# Altium schematic sheet TRINKIEROCKS P3.SchDoc
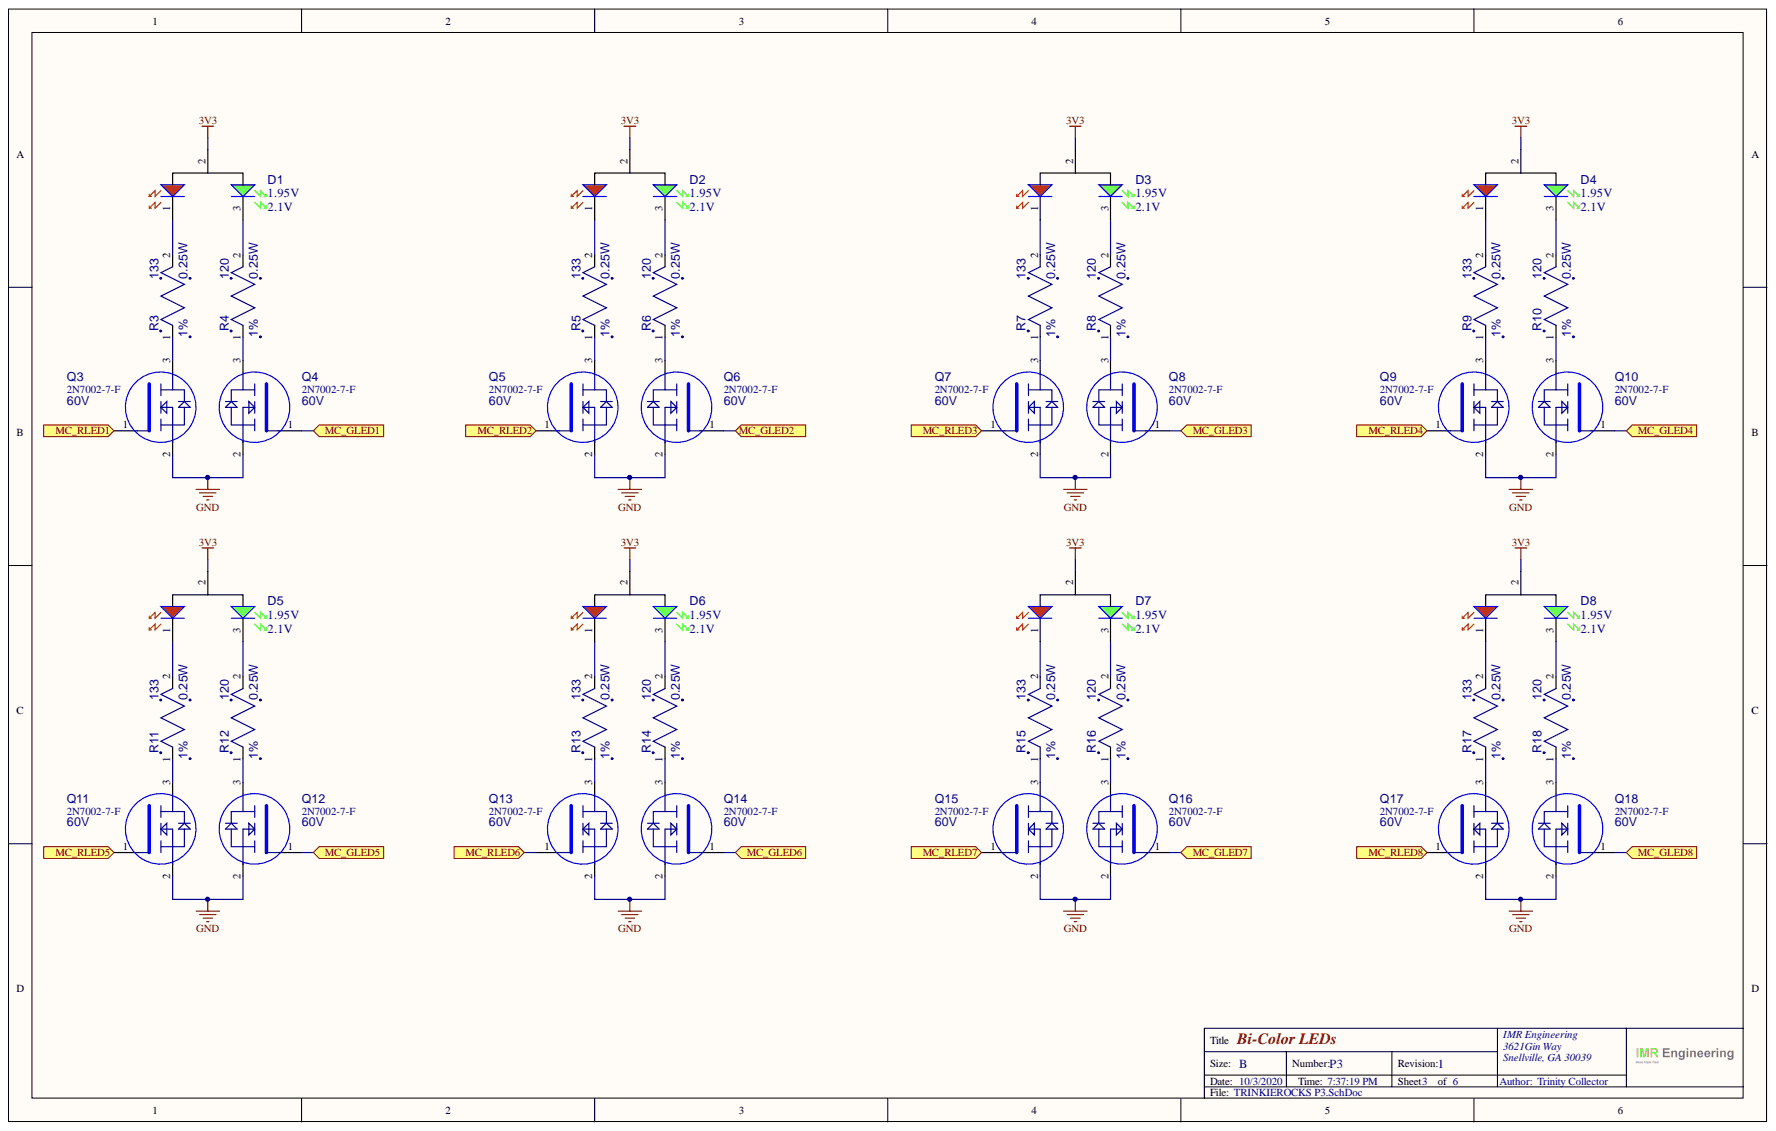
[diagram p0: TRINKIEROCKS P3.SchDoc]

## Parts
D1  Value 1: 1.95V  Value 2: 2.1V  [BICOLOR]  (hidden comment: DIODE_LED_BICOLOR_T1)
D2  Value 1: 1.95V  Value 2: 2.1V  [BICOLOR]  (hidden comment: DIODE_LED_BICOLOR_T1)
D3  Value 1: 1.95V  Value 2: 2.1V  [BICOLOR]  (hidden comment: DIODE_LED_BICOLOR_T1)
D4  Value 1: 1.95V  Value 2: 2.1V  [BICOLOR]  (hidden comment: DIODE_LED_BICOLOR_T1)
D5  Value 1: 1.95V  Value 2: 2.1V  [BICOLOR]  (hidden comment: DIODE_LED_BICOLOR_T1)
D6  Value 1: 1.95V  Value 2: 2.1V  [BICOLOR]  (hidden comment: DIODE_LED_BICOLOR_T1)
D7  Value 1: 1.95V  Value 2: 2.1V  [BICOLOR]  (hidden comment: DIODE_LED_BICOLOR_T1)
D8  Value 1: 1.95V  Value 2: 2.1V  [BICOLOR]  (hidden comment: DIODE_LED_BICOLOR_T1)
Q3  2N7002-7-F  value 60V  [SOT23 - TRANSISTOR]
Q4  2N7002-7-F  value 60V  [SOT23 - TRANSISTOR]
Q5  2N7002-7-F  value 60V  [SOT23 - TRANSISTOR]
Q6  2N7002-7-F  value 60V  [SOT23 - TRANSISTOR]
Q7  2N7002-7-F  value 60V  [SOT23 - TRANSISTOR]
Q8  2N7002-7-F  value 60V  [SOT23 - TRANSISTOR]
Q9  2N7002-7-F  value 60V  [SOT23 - TRANSISTOR]
Q10  2N7002-7-F  value 60V  [SOT23 - TRANSISTOR]
Q11  2N7002-7-F  value 60V  [SOT23 - TRANSISTOR]
Q12  2N7002-7-F  value 60V  [SOT23 - TRANSISTOR]
Q13  2N7002-7-F  value 60V  [SOT23 - TRANSISTOR]
Q14  2N7002-7-F  value 60V  [SOT23 - TRANSISTOR]
Q15  2N7002-7-F  value 60V  [SOT23 - TRANSISTOR]
Q16  2N7002-7-F  value 60V  [SOT23 - TRANSISTOR]
Q17  2N7002-7-F  value 60V  [SOT23 - TRANSISTOR]
Q18  2N7002-7-F  value 60V  [SOT23 - TRANSISTOR]
R3  value 133  POWER: 0.25W  TOLERANCE: 1%  [RES_0805]  (hidden comment: RK73H2ATTD1330F)
R4  value 120  POWER: 0.25W  TOLERANCE: 1%  [RES_0805]  (hidden comment: RK73H2ATTD1200F)
R5  value 133  POWER: 0.25W  TOLERANCE: 1%  [RES_0805]  (hidden comment: RK73H2ATTD1330F)
R6  value 120  POWER: 0.25W  TOLERANCE: 1%  [RES_0805]  (hidden comment: RK73H2ATTD1200F)
R7  value 133  POWER: 0.25W  TOLERANCE: 1%  [RES_0805]  (hidden comment: RK73H2ATTD1330F)
R8  value 120  POWER: 0.25W  TOLERANCE: 1%  [RES_0805]  (hidden comment: RK73H2ATTD1200F)
R9  value 133  POWER: 0.25W  TOLERANCE: 1%  [RES_0805]  (hidden comment: RK73H2ATTD1330F)
R10  value 120  POWER: 0.25W  TOLERANCE: 1%  [RES_0805]  (hidden comment: RK73H2ATTD1200F)
R11  value 133  POWER: 0.25W  TOLERANCE: 1%  [RES_0805]  (hidden comment: RK73H2ATTD1330F)
R12  value 120  POWER: 0.25W  TOLERANCE: 1%  [RES_0805]  (hidden comment: RK73H2ATTD1200F)
R13  value 133  POWER: 0.25W  TOLERANCE: 1%  [RES_0805]  (hidden comment: RK73H2ATTD1330F)
R14  value 120  POWER: 0.25W  TOLERANCE: 1%  [RES_0805]  (hidden comment: RK73H2ATTD1200F)
R15  value 133  POWER: 0.25W  TOLERANCE: 1%  [RES_0805]  (hidden comment: RK73H2ATTD1330F)
R16  value 120  POWER: 0.25W  TOLERANCE: 1%  [RES_0805]  (hidden comment: RK73H2ATTD1200F)
R17  value 133  POWER: 0.25W  TOLERANCE: 1%  [RES_0805]  (hidden comment: RK73H2ATTD1330F)
R18  value 120  POWER: 0.25W  TOLERANCE: 1%  [RES_0805]  (hidden comment: RK73H2ATTD1200F)

## Ports
MC_GLED1, MC_GLED2, MC_GLED3, MC_GLED4, MC_GLED5, MC_GLED6, MC_GLED7, MC_GLED8, MC_RLED1, MC_RLED2, MC_RLED3, MC_RLED4, MC_RLED5, MC_RLED6, MC_RLED7, MC_RLED8

## Nets
3V3: D1.2 D2.2 D3.2 D4.2 D5.2 D6.2 D7.2 D8.2
GND: Q3.2 Q4.2 Q5.2 Q6.2 Q7.2 Q8.2 Q9.2 Q10.2 Q11.2 Q12.2 Q13.2 Q14.2 Q15.2 Q16.2 Q17.2 Q18.2
MC_GLED1: Q4.1 ; port MC_GLED1
MC_GLED2: Q6.1 ; port MC_GLED2
MC_GLED3: Q8.1 ; port MC_GLED3
MC_GLED4: Q10.1 ; port MC_GLED4
MC_GLED5: Q12.1 ; port MC_GLED5
MC_GLED6: Q14.1 ; port MC_GLED6
MC_GLED7: Q16.1 ; port MC_GLED7
MC_GLED8: Q18.1 ; port MC_GLED8
MC_RLED1: Q3.1 ; port MC_RLED1
MC_RLED2: Q5.1 ; port MC_RLED2
MC_RLED3: Q7.1 ; port MC_RLED3
MC_RLED4: Q9.1 ; port MC_RLED4
MC_RLED5: Q11.1 ; port MC_RLED5
MC_RLED6: Q13.1 ; port MC_RLED6
MC_RLED7: Q15.1 ; port MC_RLED7
MC_RLED8: Q17.1 ; port MC_RLED8
NetD1_1: D1.1 R3.2
NetD1_3: D1.3 R4.2
NetD2_1: D2.1 R5.2
NetD2_3: D2.3 R6.2
NetD3_1: D3.1 R7.2
NetD3_3: D3.3 R8.2
NetD4_1: D4.1 R9.2
NetD4_3: D4.3 R10.2
NetD5_1: D5.1 R11.2
NetD5_3: D5.3 R12.2
NetD6_1: D6.1 R13.2
NetD6_3: D6.3 R14.2
NetD7_1: D7.1 R15.2
NetD7_3: D7.3 R16.2
NetD8_1: D8.1 R17.2
NetD8_3: D8.3 R18.2
NetQ3_3: Q3.3 R3.1
NetQ4_3: Q4.3 R4.1
NetQ5_3: Q5.3 R5.1
NetQ6_3: Q6.3 R6.1
NetQ7_3: Q7.3 R7.1
NetQ8_3: Q8.3 R8.1
NetQ9_3: Q9.3 R9.1
NetQ10_3: Q10.3 R10.1
NetQ11_3: Q11.3 R11.1
NetQ12_3: Q12.3 R12.1
NetQ13_3: Q13.3 R13.1
NetQ14_3: Q14.3 R14.1
NetQ15_3: Q15.3 R15.1
NetQ16_3: Q16.3 R16.1
NetQ17_3: Q17.3 R17.1
NetQ18_3: Q18.3 R18.1

## Library data of the parts
D1-D8 = DIODE_LED_BICOLOR_T1: DIODE,LED GREEN 1206 SMD; MFG: SunLED; MFG_PN: XLMDKVG59MCA; Case/Package: T-1 3/4; Component Kind: Standard; Supplier 1: Digi-Key; Supplier Part Number 1: 1497-1357-ND; Library Name: Trinkie.SchLib
Q3-Q18 = MOSFET_NCH_2N7002_115MA: MOSFET N CHN, VDS=60V, 115 mA, SOT 23; MFG: DIODES INC; Case/Package: SOT23; Component Kind: Standard; MFG_PN: 2N7002-7-F; Supplier 1: Digi-Key; Supplier Part Number 1: 2N7002-FDICT-ND; Library Name: Trinkie.SchLib
R3 R5 R7 R9 R11 R13 R15 R17 = RES_133_0805_1/4W_1%: 133 Ohms ±1% 0.25W, 1/4W Chip Resistor 0805 (2012 Metric) Automotive AEC-Q200, Moisture Resistant Thick Film; MFG: KOA Speer Electronics, Inc.; Case/Package: 0805; Component Kind: Standard; MFG_PN: RK73H2ATTD1330F; Supplier 1: Digi-Key; Supplier Part Number 1: 2019-RK73H2ATTD1330FCT-ND; Library Name: Trinkie.SchLib
R4 R6 R8 R10 R12 R14 R16 R18 = RES_120_0805_1/4W_1%: 120 Ohms ±1% 0.25W, 1/4W Chip Resistor 0805 (2012 Metric) Automotive AEC-Q200, Moisture Resistant Thick Film; MFG: KOA Speer Electronics, Inc.; Case/Package: 0805; Component Kind: Standard; MFG_PN: RK73H2ATTD1200F; Supplier 1: Digi-Key; Supplier Part Number 1: 2019-RK73H2ATTD1200FCT-ND; Library Name: Trinkie.SchLib
